# Altium schematic sheet 1_Power_stages.SchDoc
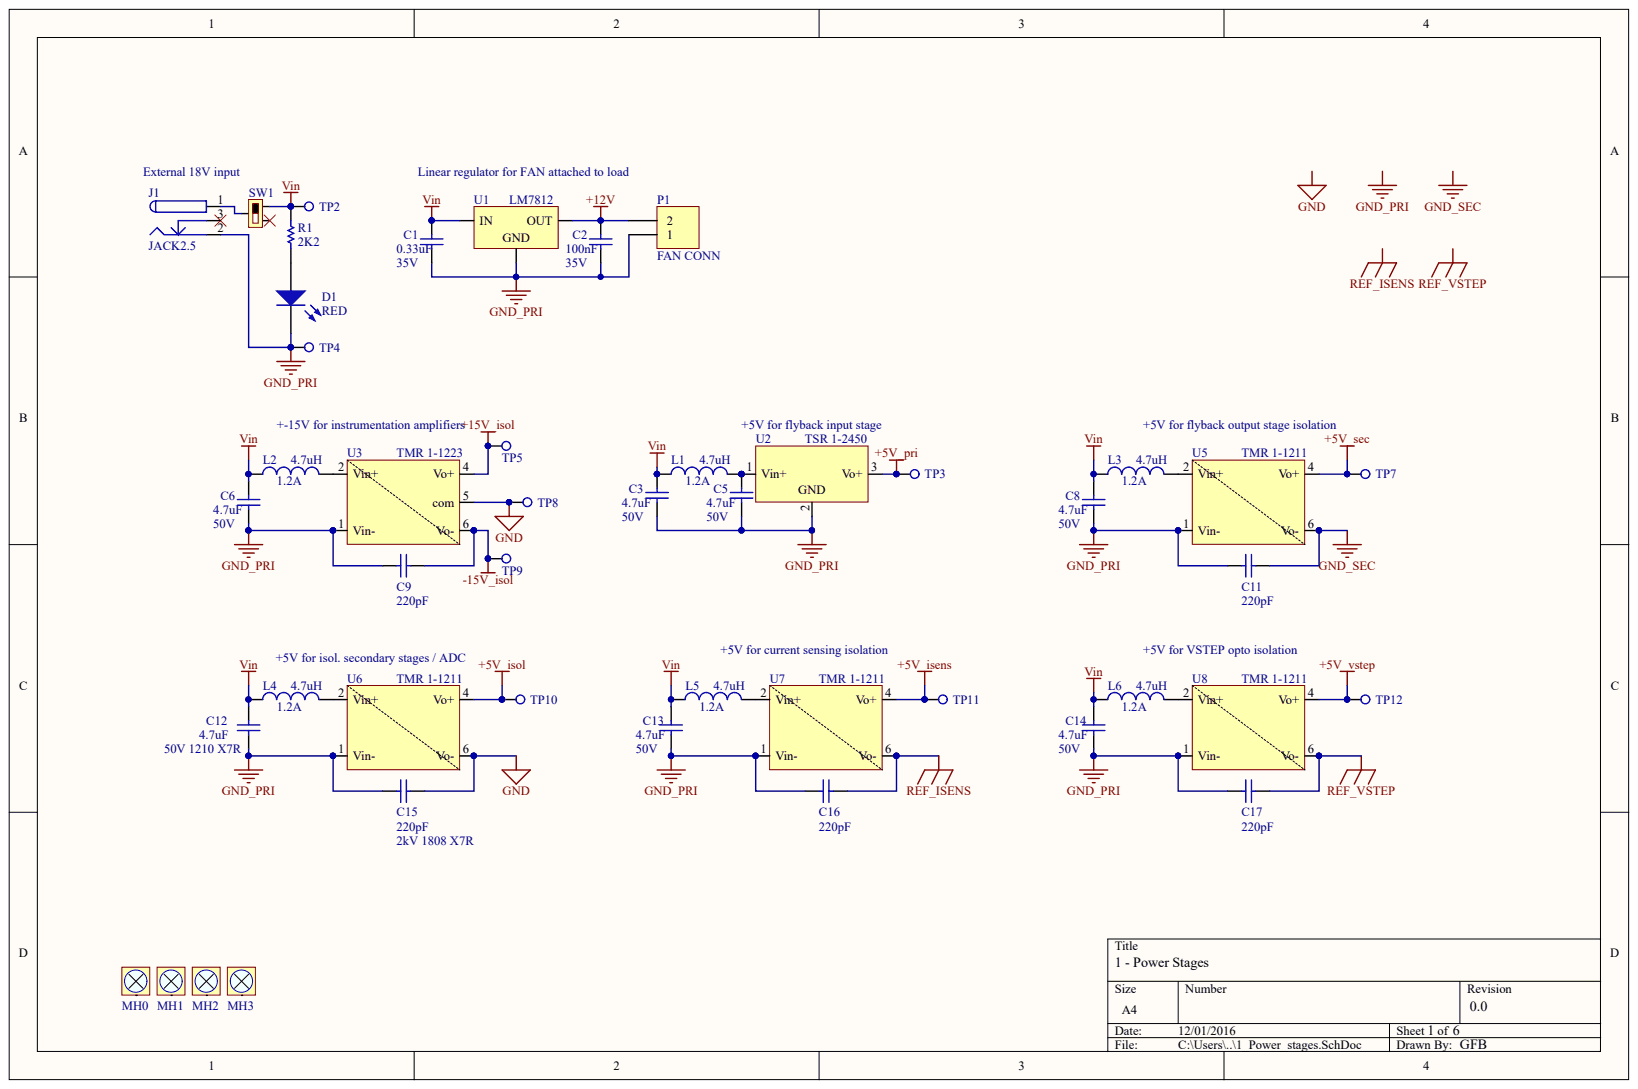
[diagram p0: 1_Power_stages.SchDoc]

## Parts
C1  35V  value 0.33uF
C2  35V  value 100nF
C3  50V  value 4.7uF
C5  50V  value 4.7uF
C6  50V  value 4.7uF
C8  50V  value 4.7uF
C9  value 220pF
C11  2kV 1808 X7R  value 220pF
C12  50V 1210 X7R  value 4.7uF
C13  50V  value 4.7uF
C14  50V  value 4.7uF
C15  2kV 1808 X7R  value 220pF
C16  2kV 1808 X7R  value 220pF
C17  2kV 1808 X7R  value 220pF
D1  RED
J1  JACK2.5
L1  1.2A  value 4.7uH
L2  1.2A  value 4.7uH
L3  1.2A  value 4.7uH
L4  1.2A  value 4.7uH
L5  1.2A  value 4.7uH
L6  1.2A  value 4.7uH
MH0  MH
MH1  MH
MH2  MH
MH3  MH
P1  FAN CONN
R1  value 2K2  (hidden comment: Res1)
SW1  PWR_SWITCH  (value not drawn)
TP2  TestPoint  (value not drawn)
TP3  TestPoint  (value not drawn)
TP4  TestPoint  (value not drawn)
TP5  TestPoint  (value not drawn)
TP7  TestPoint  (value not drawn)
TP8  TestPoint  (value not drawn)
TP9  TestPoint  (value not drawn)
TP10  TestPoint  (value not drawn)
TP11  TestPoint  (value not drawn)
TP12  TestPoint  (value not drawn)
U1  LM7812
U2  TSR 1-2450
U3  TMR 1-1223
U5  TMR 1-1211
U6  TMR 1-1211
U7  TMR 1-1211
U8  TMR 1-1211

## Nets
+5V_ISENS: TP11.1 U7.4(Vo+)
+5V_ISOL: TP10.1 U6.4(Vo+)
+5V_PRI: TP3.1 U2.3
+5V_SEC: TP7.1 U5.4(Vo+)
+5V_VSTEP: TP12.1 U8.4(Vo+)
+12V: C2.2 P1.2 U1.3
+15V_ISOL: TP5.1 U3.4(Vo+)
-15V_ISOL: C9.1 TP9.1 U3.6(Vo-)
GND: C15.1 TP8.1 U3.5(com) U6.6(Vo-)
GND_PRI: C1.1 C2.1 C3.1 C5.1 C6.1 C8.1 C9.2 C11.2 C12.1 C13.1 C14.1 C15.2 C16.2 C17.2 D1.K J1.2 P1.1 TP4.1 U1.2 U2.2 U3.1(Vin-) U5.1(Vin-) U6.1(Vin-) U7.1(Vin-) U8.1(Vin-)
GND_SEC: C11.1 U5.6(Vo-)
REF_ISENS: C16.1 U7.6(Vo-)
REF_VSTEP: C17.1 U8.6(Vo-)
VIN: C1.2 C3.2 C6.2 C8.2 C12.2 C13.2 C14.2 L1.1 L2.1 L3.1 L4.1 L5.1 L6.1 R1.2 SW1.1 TP2.1 U1.1
NetC5_2: C5.2 L1.2 U2.1
NetD1_A: D1.A R1.1
NetJ1_1: J1.1 SW1.2
NetL2_2: L2.2 U3.2(Vin+)
NetL3_2: L3.2 U5.2(Vin+)
NetL4_2: L4.2 U6.2(Vin+)
NetL5_2: L5.2 U7.2(Vin+)
NetL6_2: L6.2 U8.2(Vin+)

Not connected: J1 3; MH0 3; MH1 3; MH2 3; MH3 3; SW1 3

## Library data of the parts
J1 = (no symbol name): RS: 487-836; Published: 17-Dec-2003; DatasheetDocument: 09-May-2002; ComponentLink1Description: Manufacturer Link; ComponentLink1URL: http://domino.kycon.com/internet/Products.html; Publisher: Altium Limited
L1-L6 = (no symbol name): Manufacturer: BOURNS; Manufacturer Part Number: SDR0302-4R7ML; Code_IEC: 1005; Published: 8-Jun-2000; LatestRevisionDate: 29-May-2009; LatestRevisionNote: IPC-7351 Footprint Added.; PackageReference: 0402-A; PackageDescription: Chip Inductor; Code_JEITA: 0402; Supplier 1: Farnell; Supplier Part Number 1: 2212484RL; RoHS: YES; Stock 1: 3413; Pricing 1: 150=0,327, 200=0,284, 500=0,246, 1000=0,225, 2000=0,192, 10000=0,174, 20000=0,171 (EUR); ComponentLink1URL: http://www.farnell.com/datasheets/1843973.pdf; ComponentLink1Description: http://www.farnell.com/datasheets/1843973.pdf; Supplier 2: RS; Supplier Part Number 2: 727-1574; Publisher: Altium Limited
SW1 = (no symbol name): RS: 734-7292
TP2-TP5 TP7-TP12 = (no symbol name): RS: 262-2185
U3 = (no symbol name): Manufacturer: TRACOPOWER; Supplier 1: Newark; Supplier Part Number 1: 13M3241; Manufacturer Part Number: TMR 1223; RoHS: YES; Stock 1: 186; Pricing 1: 1=20,75, 50=18,82, 100=17,24, 250=16,02, 500=14,97 (USD); ComponentLink1URL: http://www.farnell.com/datasheets/1537625.pdf; ComponentLink1Description: http://www.farnell.com/datasheets/1537625.pdf; ComponentLink2URL: http://www.farnell.com/datasheets/1648752.pdf; ComponentLink2Description: http://www.farnell.com/datasheets/1648752.pdf; ComponentLink3URL: http://www.farnell.com/datasheets/1763643.pdf; ComponentLink3Description: http://www.farnell.com/datasheets/1763643.pdf
U5-U8 = (no symbol name): Manufacturer: TRACOPOWER; DC / DC Converter Type: Isolated POL; DC / DC Converter Output Type: Fixed; DC / DC Converter Mounting: Through Hole; Output Power Max: 2; Output Current Max: 400; Input Voltage DC Min: 9; Supplier 1: Newark; Supplier Part Number 1: 51R5458; Manufacturer Part Number: TMR 1211; RoHS: YES; Stock 1: 5; Pricing 1: 10=17,18, 30=16,38, 50=15,43 (USD); ComponentLink1URL: http://www.farnell.com/datasheets/1749862.pdf; ComponentLink1Description: http://www.farnell.com/datasheets/1749862.pdf; ComponentLink2URL: http://www.tracopower.com/fileadmin/medien/dokumente/pdf/datasheets/tmr.pdf; ComponentLink2Description: http://www.tracopower.com/fileadmin/medien/dokumente/pdf/datasheets/tmr.pdf
C1-C3 C5 C6 C8 C9 C11-C17 D1 MH0-MH3 P1 R1 U1 U2 = (no symbol name)
  C1-C3 C5 C6 C8 C9 C11-C17: Published: 8-Jun-2000; LatestRevisionDate: 17-Jul-2002; LatestRevisionNote: Re-released for DXP Platform.; PackageReference: RAD-0.3; PackageDescription: Radial Cap, Thru-Hole; 2 Leads; 0.3 in Pin Spacing
  D1: Published: 8-Jun-2000; LatestRevisionDate: 08-Jul-2005; LatestRevisionNote: Mech Layer 1 removed.; PackageReference: LED-0; PackageDescription: LED; 2 Leads
  P1: LatestRevisionDate: 17-Jul-2002; LatestRevisionNote: Re-released for DXP Platform.
  R1: Published: 8-Jun-2000; LatestRevisionDate: 17-Jul-2002; LatestRevisionNote: Re-released for DXP Platform.; PackageReference: AXIAL-0.3; PackageDescription: Axial Device, Thru-Hole; 2 Leads; 0.3 in Pin Spacing
  C1-C3 C5 C6 C8 C9 C11-C17 D1 P1 R1: Publisher: Altium Limited
